# Altium schematic sheet BUTTON.SchDoc
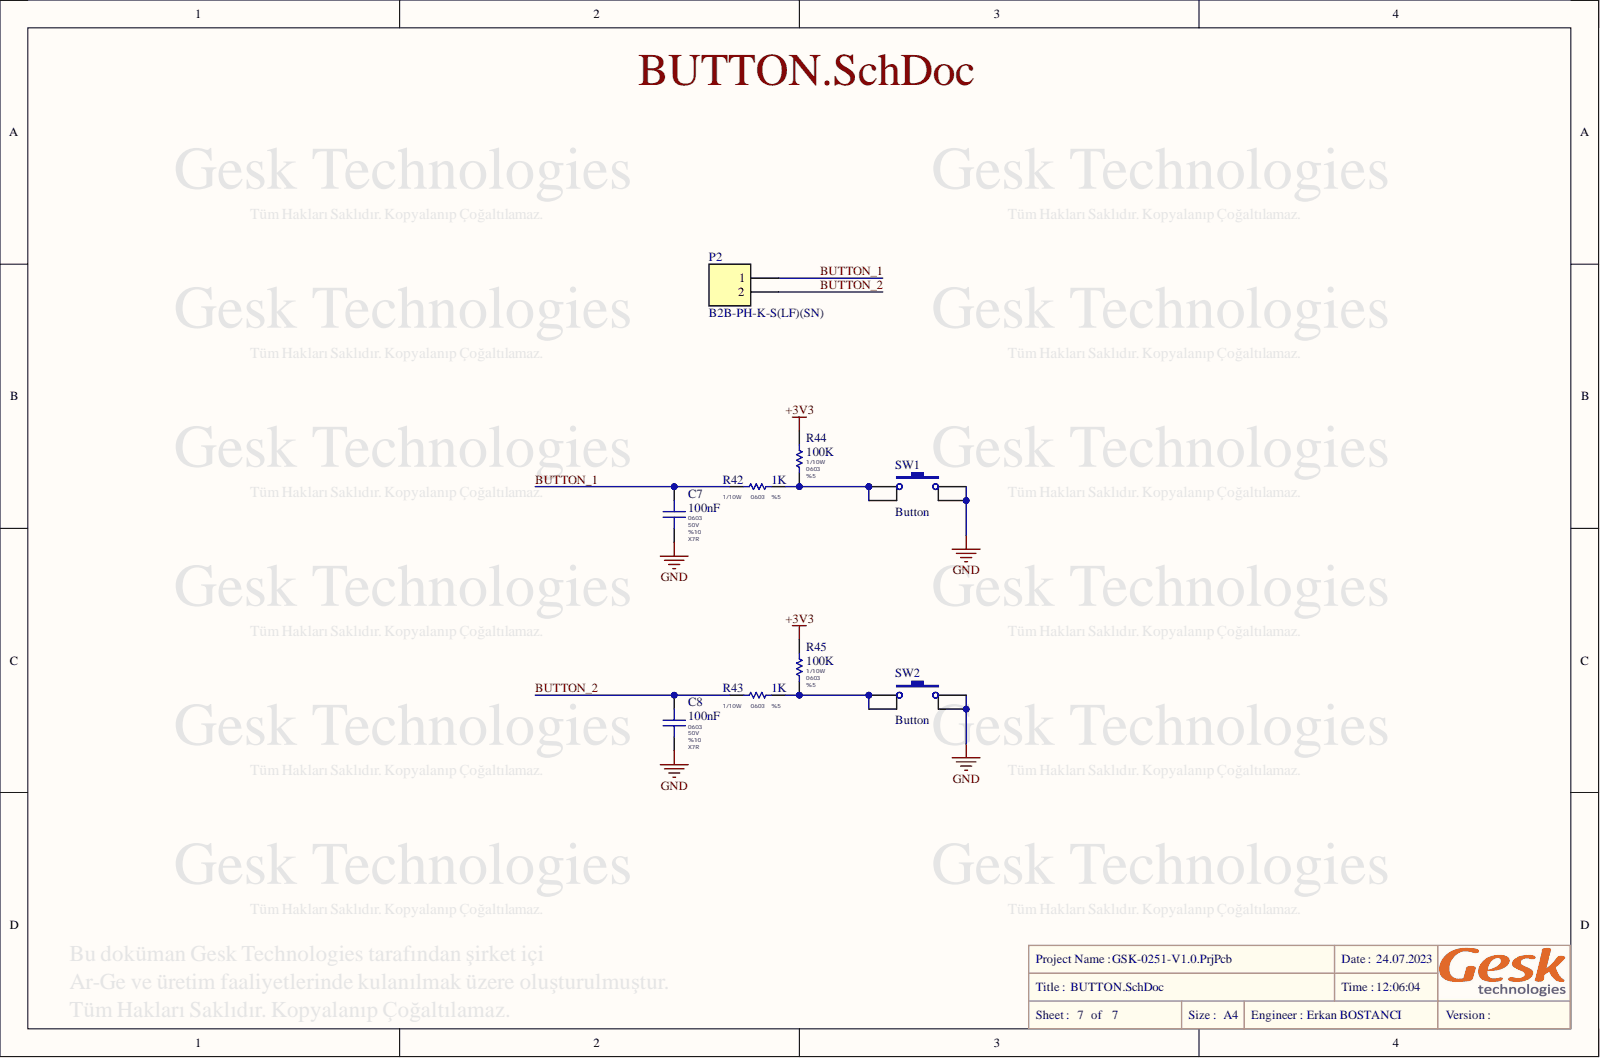
[diagram p0: BUTTON.SchDoc]

## Parts
C7  100nF  Package / Case: 0603  Temperature Coefficient: X7R  Tolerance: %10  Voltage: 50V  [SM/C0603N]
C8  100nF  Package / Case: 0603  Temperature Coefficient: X7R  Tolerance: %10  Voltage: 50V  [SM/C0603N]
P2  B2B-PH-K-S(LF)(SN)  [B2B-PH]
R42  1K  Package: 0603  Power: 1/10W  Tolerance: %5  [SM/R0603N]
R43  1K  Package: 0603  Power: 1/10W  Tolerance: %5  [SM/R0603N]
R44  100K  Package: 0603  Power: 1/10W  Tolerance: %5  [SM/R0603N]
R45  100K  Package: 0603  Power: 1/10W  Tolerance: %5  [SM/R0603N]
SW1  Button  [Tacticle Switch H14mm]
SW2  Button  [Tacticle Switch H14mm]

## Nets
+3V3: R44.2 R45.2
GND: C7.1 C8.1 SW1.1 SW1.2 SW2.1 SW2.2
BUTTON_1: C7.2 P2.1 R42.2
BUTTON_2: C8.2 P2.2 R43.2
NetR42_1: R42.1 R44.1 SW1.3 SW1.4
NetR43_1: R43.1 R45.1 SW2.3 SW2.4

## Library data of the parts
C7 C8 = CapNP: CAP CER 0.1UF 50V X7R 0603; Manufacturer Part Number: smd cap; Component Kind: Standard; Signal Integrity: Cap; Simulation: CAP; Value: 100nF; Library Name: Passives_GESK.IntLib
P2 = B2B-PH-K: Connector Header Through Hole 2 position 0.079" (2.00mm); Manufacturer: JST Sales America Inc.; Current Max: 3A; Category: Connectors, Interconnects; Component Kind: Standard; ComponentLink1Description: http://media.digikey.com/pdf/Data%20Sheets/Phoenix%20Contact%20PDFs/1757268.pdf; ComponentLink1URL: http://www.jst-mfg.com/product/pdf/eng/eXH.pdf; Manufacturer Part Number: B2B-PH-K-S(LF)(SN); Pitch: 2.00mm; Library Name: Connectors_GESK.IntLib
R42 R43 = R: RES SMD 1K OHM 5% 1/10W 0603; Manufacturer Part Number: Smd Res; Component Kind: Standard; Signal Integrity: Resistor; Value: 1K; Library Name: Passives_GESK.IntLib
R44 R45 = R: RES SMD 100K OHM 5% 1/10W 0603; Manufacturer Part Number: Smd Res; Component Kind: Standard; Signal Integrity: Resistor; Value: 100K; Library Name: Passives_GESK.IntLib
SW1 SW2 = Button: TACT SWITCH 6.00x6.00 H-14.00mm THT V/T; Values: Tact Switch 6x6x10mm; Category: Switches; Component Kind: Standard; Mounting Type: Through Hole; Library Name: Passives_GESK.IntLib
